# Altium schematic sheet config_headers.SchDoc(config_headers)
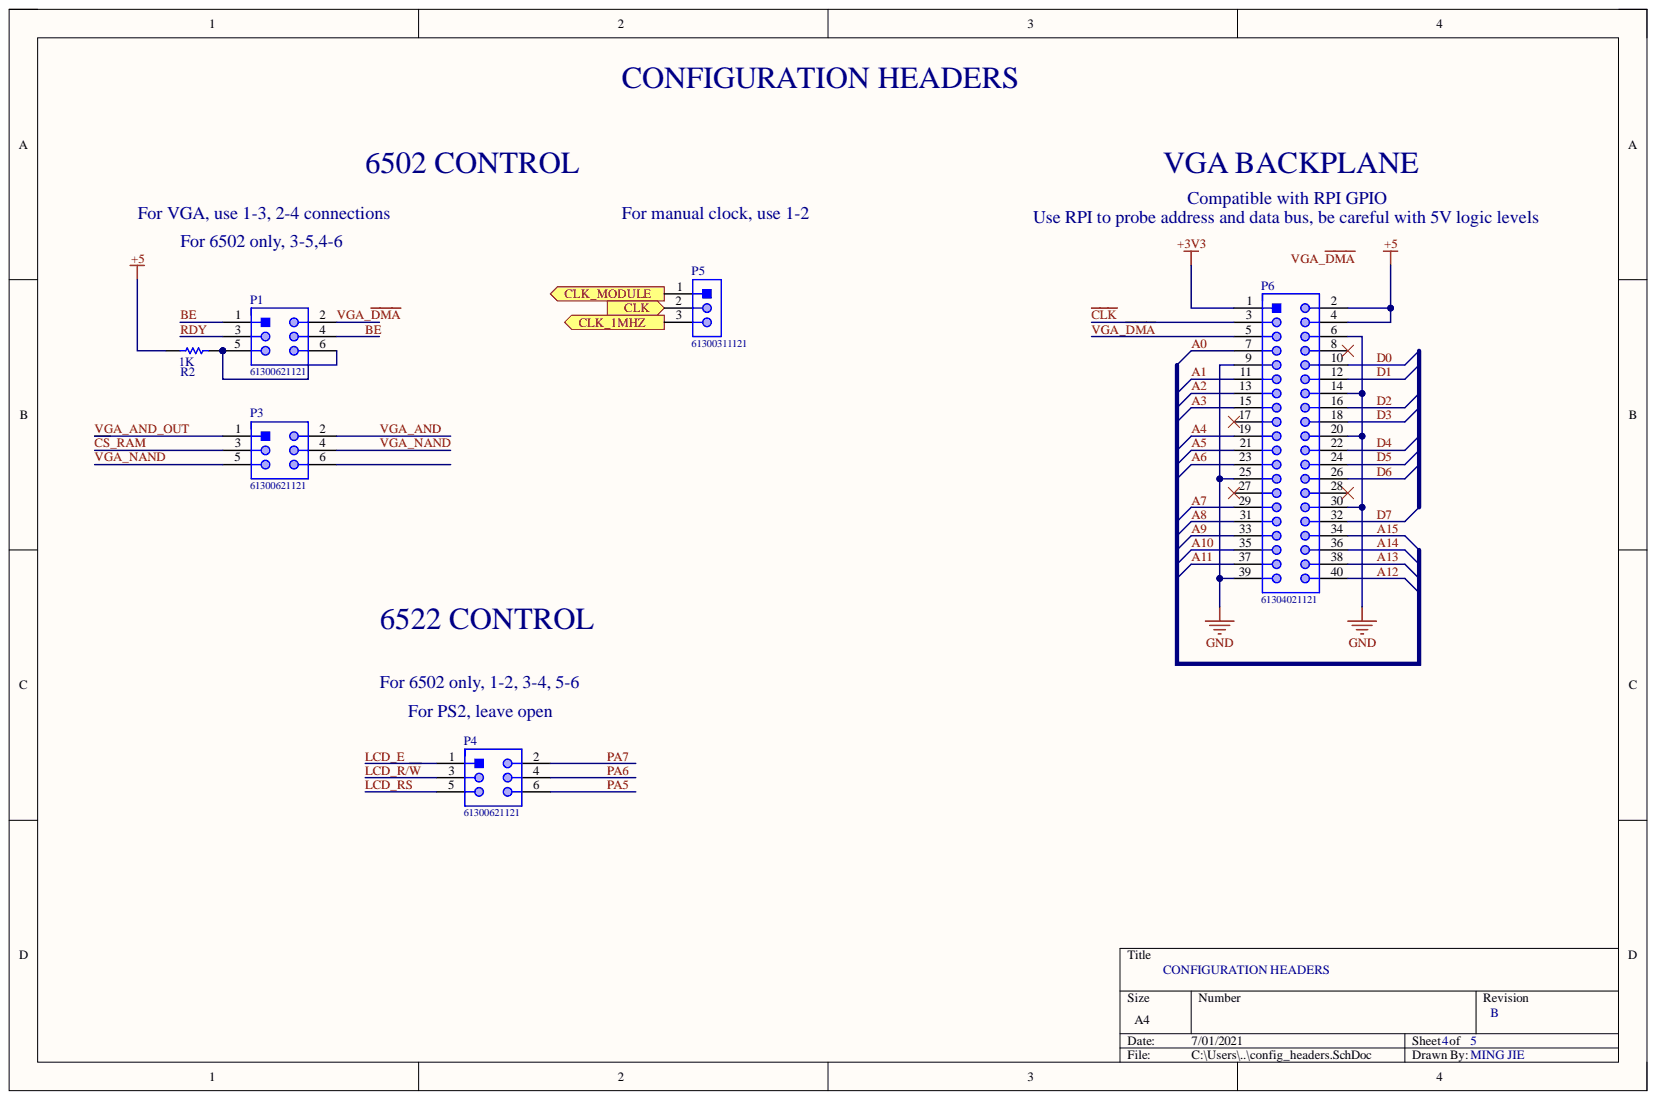
[diagram p0: config_headers.SchDoc]

## Parts
P1  61300621121  [61300621121]
P3  61300621121  [61300621121]
P4  61300621121  [61300621121]
P5  61300311121  [61300311121]
P6  61304021121  [61304021121]
R2  Resistance: 1K  [RESC2013X50X30NL20T20]  (hidden comment: CRCW08051K00FKEA)

## Ports
CLK, CLK_1MHZ, CLK_MODULE

## Nets
+3V3: P6.1
+5: P6.2 P6.4 R2.1
GND: P6.6 P6.9 P6.14 P6.20 P6.25 P6.30 P6.39
A0: P6.7
A1: P6.11
A2: P6.13
A3: P6.15
A4: P6.19
A5: P6.21
A6: P6.23
A7: P6.29
A8: P6.31
A9: P6.33
A10: P6.35
A11: P6.37
A12: P6.40
A13: P6.38
A14: P6.36
A15: P6.34
BE: P1.1 P1.4
~{CLK}: P6.3
~{CS}_RAM: P3.3
CLK: P5.2 ; port CLK
CLK_1MHZ: P5.3 ; port CLK_1MHZ
CLK_MODULE: P5.1 ; port CLK_MODULE
D0: P6.10
D1: P6.12
D2: P6.16
D3: P6.18
D4: P6.22
D5: P6.24
D6: P6.26
D7: P6.32
LCD_E: P4.1
LCD_R/~{W}: P4.3
LCD_RS: P4.5
PA5: P4.6
PA6: P4.4
PA7: P4.2
RDY: P1.3
VGA_AND: P3.2
VGA_AND_OUT: P3.1
VGA_~{DMA}: P1.2 P6.5
VGA_NAND: P3.4 P3.5
NetP1_5: P1.5 P1.6 R2.2

Not connected: P3 6; P6 8 17 27 28

## Library data of the parts
P1 P3 P4 = CMP-1502-01072-1: THT Vertical Pin Header WR-PHD, Pitch 2.54 mm, Dual Row, 6 pins; Manufacturer: Wurth Elektronik; Contact Resistance: 20mOhm; Gender: Male; Pin Number: 6; Pitch: 2.54mm; Rated Current: 3A; Withstanding Voltage: 500VAC; Working Voltage: 250VAC; Component Kind: Standard; Datasheet URL: https://katalog.we-online.de/em/datasheet/6130xx21121.pdf; Library Name: Altium Content Vault; Manufacturer URL: http://www.we-online.de; Mounting Technology: THT; Operating Temperature: -40to105degC; Orientation: Vertical; Package Reference: 61300621121; Packaging: Bag; Part Number: 61300621121; Row Number: Dual; Publisher: WurthElektronik
P5 = CMP-1502-01062-1: THT Vertical Pin Header WR-PHD, Pitch 2.54 mm, Single Row, 3 pins; Manufacturer: Wurth Elektronik; Contact Resistance: 20mOhm; Gender: Male; Pin Number: 3; Pitch: 2.54mm; Rated Current: 3A; Withstanding Voltage: 500VAC; Working Voltage: 250VAC; Component Kind: Standard; Datasheet URL: https://katalog.we-online.de/em/datasheet/6130xx11121.pdf; Library Name: Altium Content Vault; Manufacturer URL: http://www.we-online.de; Mounting Technology: THT; Operating Temperature: -40to105degC; Orientation: Vertical; Package Reference: 61300311121; Packaging: Bag; Part Number: 61300311121; Row Number: Single; Publisher: WurthElektronik
P6 = CMP-1502-01135-1: THT Vertical Pin Header WR-PHD, Pitch 2.54 mm, Dual Row, 40 pins; Manufacturer: Wurth Elektronik; Contact Resistance: 20mOhm; Gender: Male; Pin Number: 40; Pitch: 2.54mm; Rated Current: 3A; Withstanding Voltage: 500VAC; Working Voltage: 250VAC; Component Kind: Standard; Datasheet URL: https://katalog.we-online.de/em/datasheet/6130xx21121.pdf; Library Name: Altium Content Vault; Manufacturer URL: http://www.we-online.de; Mounting Technology: THT; Operating Temperature: -40to105degC; Orientation: Vertical; Package Reference: 61304021121; Packaging: Bag; Part Number: 61304021121; Row Number: Dual; Publisher: WurthElektronik
R2 = CMP-1013-00510-2: Manufacturer: Vishay Dale; Component Kind: Standard; Library Name: Altium Content Vault; Part Number: CRCW08051K00FKEA
